# Altium schematic sheet joint_board_boosterpack_load_cell.SchDoc
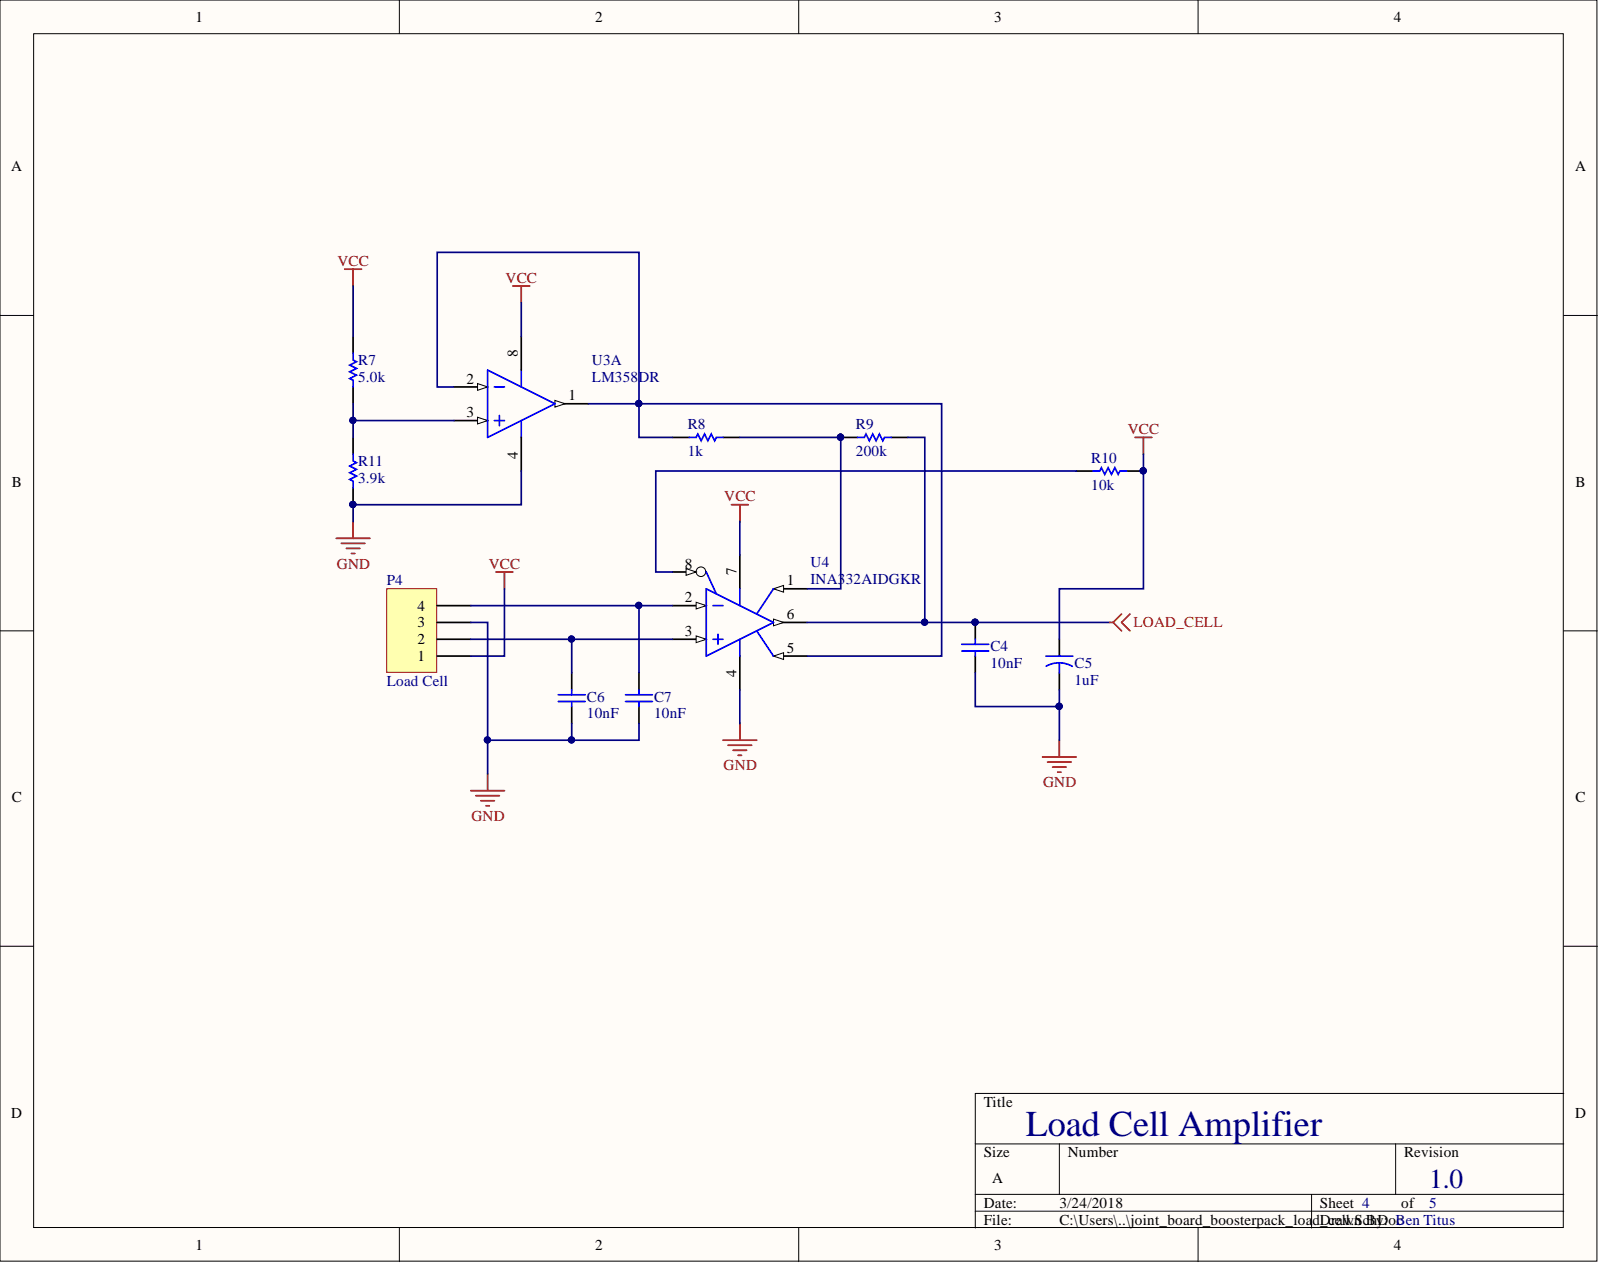
[diagram p0: joint_board_boosterpack_load_cell.SchDoc]

## Parts
C4  10nF  [CAPC0805(2012)145_L]
C5  1uF  [CAPR5-4X5]
C6  10nF  [CAPC0805(2012)145_L]
C7  10nF  [CAPC0805(2012)145_L]
P4  Load Cell  [HDR1X4]
R7  5.0k  [RESC0805(2012)_L]
R8  1k  [RESC0805(2012)_L]
R9  200k  [RESC0805(2012)_L]
R10  10k  [RESC0805(2012)_L]
R11  3.9k  [RESC0805(2012)_L]
U3  LM358DR  [D0008A_L]  (part A)
U4  INA332AIDGKR  [DGK0008A_M]

## Nets
GND: C4.1 C5.2 C6.1 C7.1 P4.3 R11.1 U3.4 U4.4
LOAD_CELL: C4.2 R9.2 U4.6
VCC: C5.1 P4.1 R7.2 R10.1 U3.8 U4.7
NetC6_2: C6.2 P4.2 U4.3
NetC7_2: C7.2 P4.4 U4.2
NetR7_1: R7.1 R11.2 U3.3
NetR8_1: R8.1 U3.1 U3.2 U4.5
NetR8_2: R8.2 R9.1 U4.1
NetR10_2: R10.2 U4.8

## Library data of the parts
C4 C6 C7 = CMP-1590-00003-1: 0805 (2012 Metric) Chip Capacitor; Case-EIA: 0805; Case-Metric: 2012; Component Kind: Standard; Library Name: Altium Content Vault; PackageReference: CAPC0805(2012)145
C5 = Cap2: Capacitor; Component Kind: Standard; Library Name: Miscellaneous Devices.IntLib; PackageDescription: Capacitor; 2 Leads; PackageReference: CAPR5-4X5; Published: 23-Sep-2002; Signal Integrity: Cap; Simulation: CAP; Value: 1uF; Publisher: Altium Limited
P4 = Header 4: Header, 4-Pin; Component Kind: Standard; LatestRevisionDate: 17-Jul-2002; LatestRevisionNote: Re-released for DXP Platform.; Library Name: Miscellaneous Connectors.IntLib; Signal Integrity: Connector; Publisher: Altium Limited
R7-R11 = CMP-1591-00002-1: 0805 (2012 Metric) Chip Resistor; Case-EIA: 0805; Case-Metric: 2012; Component Kind: Standard; Library Name: Altium Content Vault; PackageReference: RESC0805(2012)
U3 = CMP-1685-00009-1: Dual Operational Amplifier, 3 to 32 V, 0 to 70 degC, 8-Pin SOIC (D), Green (RoHS & no Sb/Br), Tape and Reel; Code_JEDEC: MS-012; Component Kind: Standard; ComponentLink1Description: Manufacturer URL; ComponentLink1URL: http://www.ti.com/; ComponentLink2Description: Datasheet; ComponentLink2URL: http://www.ti.com/lit/ds/symlink/lm358.pdf; ComponentLink3Description: Package Specification; ComponentLink3URL: http://www.ti.com/lit/ml/msoi002j/msoi002j.pdf; DatasheetVersion: SLOS068T, 04/2015; Library Name: Altium Content Vault; PackageDescription: 8-Pin Small Outline Integrated Circuit, Body 4.9 x 3.9 mm, Pitch 1.27 mm; PackageReference: D0008A; PackageVersion: 4040047-3/M, 06/2011
U4 = CMP-0944-00077-2: Low-Power, Single Supply, CMOS, Low Cost, Instrumentation Amplifier, -55 to 125 degC, 8-pin SOP (DGK8), Green (RoHS & no Sb/Br); Manufacturer: Texas Instruments; CMRR(Min)(dB): 60; Gain(V/V): 5 to 1000; Input Bias Current (+/-)(Max)(nA): 0.01; Input Offset (+/-)(Max)(uV): 8000; Noise at 1kHz(Typ)(nV/rt(Hz)): 46; Number of Channels: 1; Quiescent Current (+/-)(Typ)(mA): 0.415; Vs(Max)(V): 5.5; Vs(Min)(V): 2.5; Code_JEDEC: MO-187; Component Kind: Standard; ComponentLink1Description: Manufacturer URL; ComponentLink1URL: http://www.ti.com/; ComponentLink2Description: Datasheet; ComponentLink2URL: http://www.ti.com/general/docs/lit/getliterature.tsp?genericPartNumber=INA332&fileType=pdf; ComponentLink3Description: Package Specification; ComponentLink3URL: http://www.ti.com/litv/pdf/mpds028d; DatasheetVersion: SBOS216B; Eco-Plan: Green (RoHS & no Sb/Br); Library Name: Custom_Lib.IntLib; Mounting Technology: Surface Mount; Non-Linearity (+/-)(Max)(%): 0.01; PackageDescription: 8-Pin Thin Small Outline, Body 3 x 3 mm, Pitch 0.65 mm; PackageReference: DGK0008A; PackageVersion: 4073329/E, 05/2006; PartNumber: INA332AIDGKR; SubFamily: Single Supply
